# Altium schematic sheet MCU_and_Antenna.SchDoc
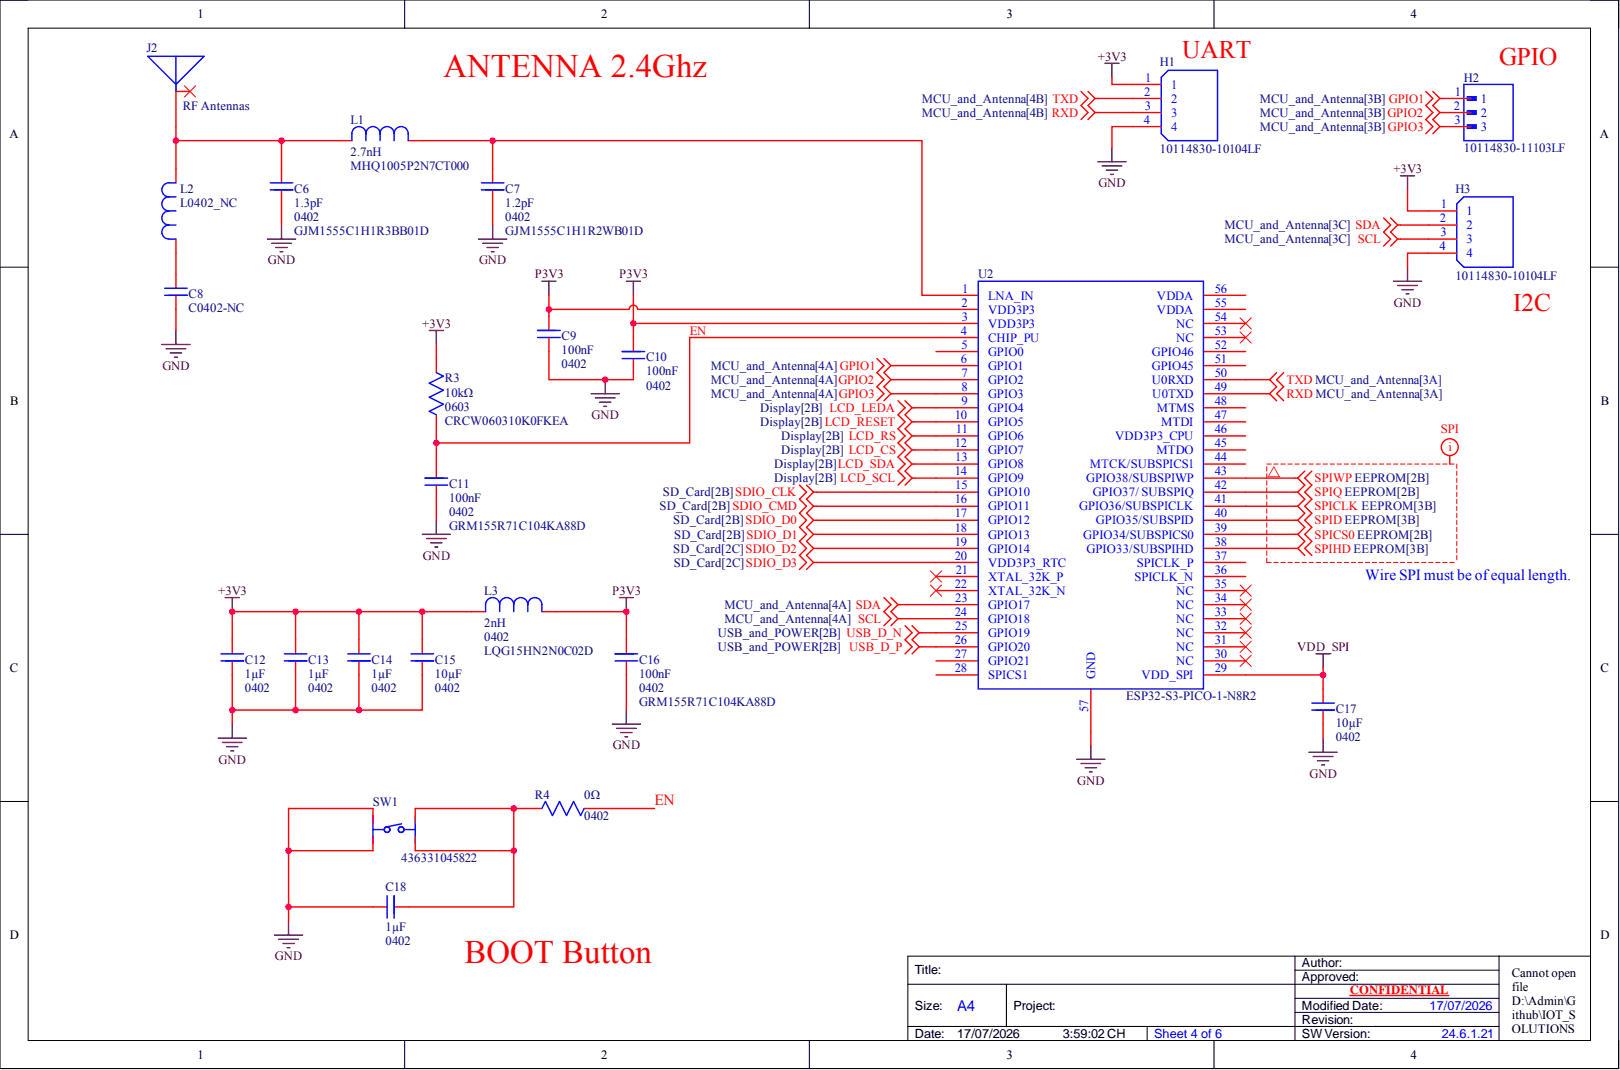
[diagram p0: MCU_and_Antenna.SchDoc]

## Parts
C6  GJM1555C1H1R3BB01D  value 1.3pF  Case Code (Imperial): 0402  [CAPC1005X55N]
C7  GJM1555C1H1R2WB01D  value 1.2pF  Case Code (Imperial): 0402  [CAPC1005X55N]
C8  C0402-NC  [CAPC1005X55N - NC]
C9  GRM155R71C104KA88D  value 100nF  Case Code (Imperial): 0402  [CAPC1005X55N]
C10  GRM155R71C104KA88D  value 100nF  Case Code (Imperial): 0402  [CAPC1005X55N]
C11  GRM155R71C104KA88D  value 100nF  Case Code (Imperial): 0402  [CAPC1005X55N]
C12  value 1µF  Case Code (Imperial): 0402  [CAPC1005X55N]  (hidden comment: CL05A105KP5NNNC)
C13  value 1µF  Case Code (Imperial): 0402  [CAPC1005X55N]  (hidden comment: CL05A105KP5NNNC)
C14  value 1µF  Case Code (Imperial): 0402  [CAPC1005X55N]  (hidden comment: CL05A105KP5NNNC)
C15  value 10µF  Case Code (Imperial): 0402  [CAPC1005X55N]  (hidden comment: GRM155R60J106ME15D)
C16  GRM155R71C104KA88D  value 100nF  Case Code (Imperial): 0402  [CAPC1005X55N]
C17  value 10µF  Case Code (Imperial): 0402  [CAPC1005X55N]  (hidden comment: GRM155R60J106ME15D)
C18  value 1µF  Case Code (Imperial): 0402  [CAPC1005X55N]  (hidden comment: CL05A105KP5NNNC)
H1  10114830-10104LF  [AMPHENOL_10114830-10104LF]
H2  10114830-11103LF  [AMPHENOL_10114830-11103LF]
H3  10114830-10104LF  [AMPHENOL_10114830-10104LF]
J2  RF Antennas  [ANT3216LL00R2400A]
L1  MHQ1005P2N7CT000  value 2.7nH  [IND_1005_TDK]
L2  L0402_NC  [IND_1005_TDK_NC]
L3  LQG15HN2N0C02D  value 2nH  Case/Package: 0402  [INDC1005X55N]
R3  CRCW060310K0FKEA  value 10kΩ  Case Code (Imperial): 0603  [RES_CRCW_0603]
R4  value 0Ω  Case Code (Imperial): 0402  [RC0402N_YAG-M]  (hidden comment: RC0402JR-070RL)
SW1  436331045822  [436331045822]
U2  ESP32-S3-PICO-1-N8R2  [QFN56_7X7_EXP-L]

## Nets
+3V3: C12.2 C13.2 C14.2 C15.2 H1.1 H3.1 L3.2 R3.1
GND: C6.1 C7.1 C8.1 C9.2 C10.2 C11.2 C12.1 C13.1 C14.1 C15.1 C16.2 C17.1 C18.2 H1.4 H3.4 SW1.1 SW1.3 U2.57(GND)
GPIO1: H2.1 U2.6(GPIO1)
GPIO2: H2.2 U2.7(GPIO2)
GPIO3: H2.3 U2.8(GPIO3)
LCD_CS: U2.12(GPIO7)
LCD_LEDA: U2.9(GPIO4)
LCD_RESET: U2.10(GPIO5)
LCD_RS: U2.11(GPIO6)
LCD_SCL: U2.14(GPIO9)
LCD_SDA: U2.13(GPIO8)
P3V3: C9.1 C10.1 C16.1 L3.1 U2.2(VDD3P3) U2.3(VDD3P3)
RXD: H1.3 U2.49(U0TXD)
SCL: H3.3 U2.24(GPIO18)
SDA: H3.2 U2.23(GPIO17)
SDIO_CLK: U2.15(GPIO10)
SDIO_CMD: U2.16(GPIO11)
SDIO_D0: U2.17(GPIO12)
SDIO_D1: U2.18(GPIO13)
SDIO_D2: U2.19(GPIO14)
SDIO_D3: U2.20(VDD3P3_RTC)
SPICLK: U2.41(GPIO36/SUBSPICLK)
SPICS0: U2.39(GPIO34/SUBSPICS0)
SPID: U2.40(GPIO35/SUBSPID)
SPIHD: U2.38(GPIO33/SUBSPIHD)
SPIQ: U2.42(GPIO37/_SUBSPIQ)
SPIWP: U2.43(GPIO38/SUBSPIWP)
TXD: H1.2 U2.50(U0RXD)
USB_D_N: U2.25(GPIO19)
USB_D_P: U2.26(GPIO20)
VDD_SPI: C17.2 U2.29(VDD_SPI)
EN: C11.1 R3.2 R4.2 U2.4(CHIP_PU)
NetC6_2: C6.2 J2.1 L1.2 L2.1
NetC7_2: C7.2 L1.1 U2.1(LNA_IN)
NetC8_2: C8.2 L2.2
NetC18_1: C18.1 R4.1 SW1.2 SW1.4

Not connected: J2 2; U2 5(GPIO0) 21(XTAL_32K_P) 22(XTAL_32K_N) 27(GPIO21) 28(SPICS1) 30(NC) 31(NC) 32(NC) 33(NC) 34(NC) 35(NC) 36(SPICLK_N) 37(SPICLK_P) 44(MTCK/SUBSPICS1) 45(MTDO) 46(VDD3P3_CPU) 47(MTDI) 48(MTMS) 51(GPIO45) 52(GPIO46) 53(NC) 54(NC) 55(VDDA) 56(VDDA)
